# Altium schematic sheet DSDADC.SchDoc(DSDADC)
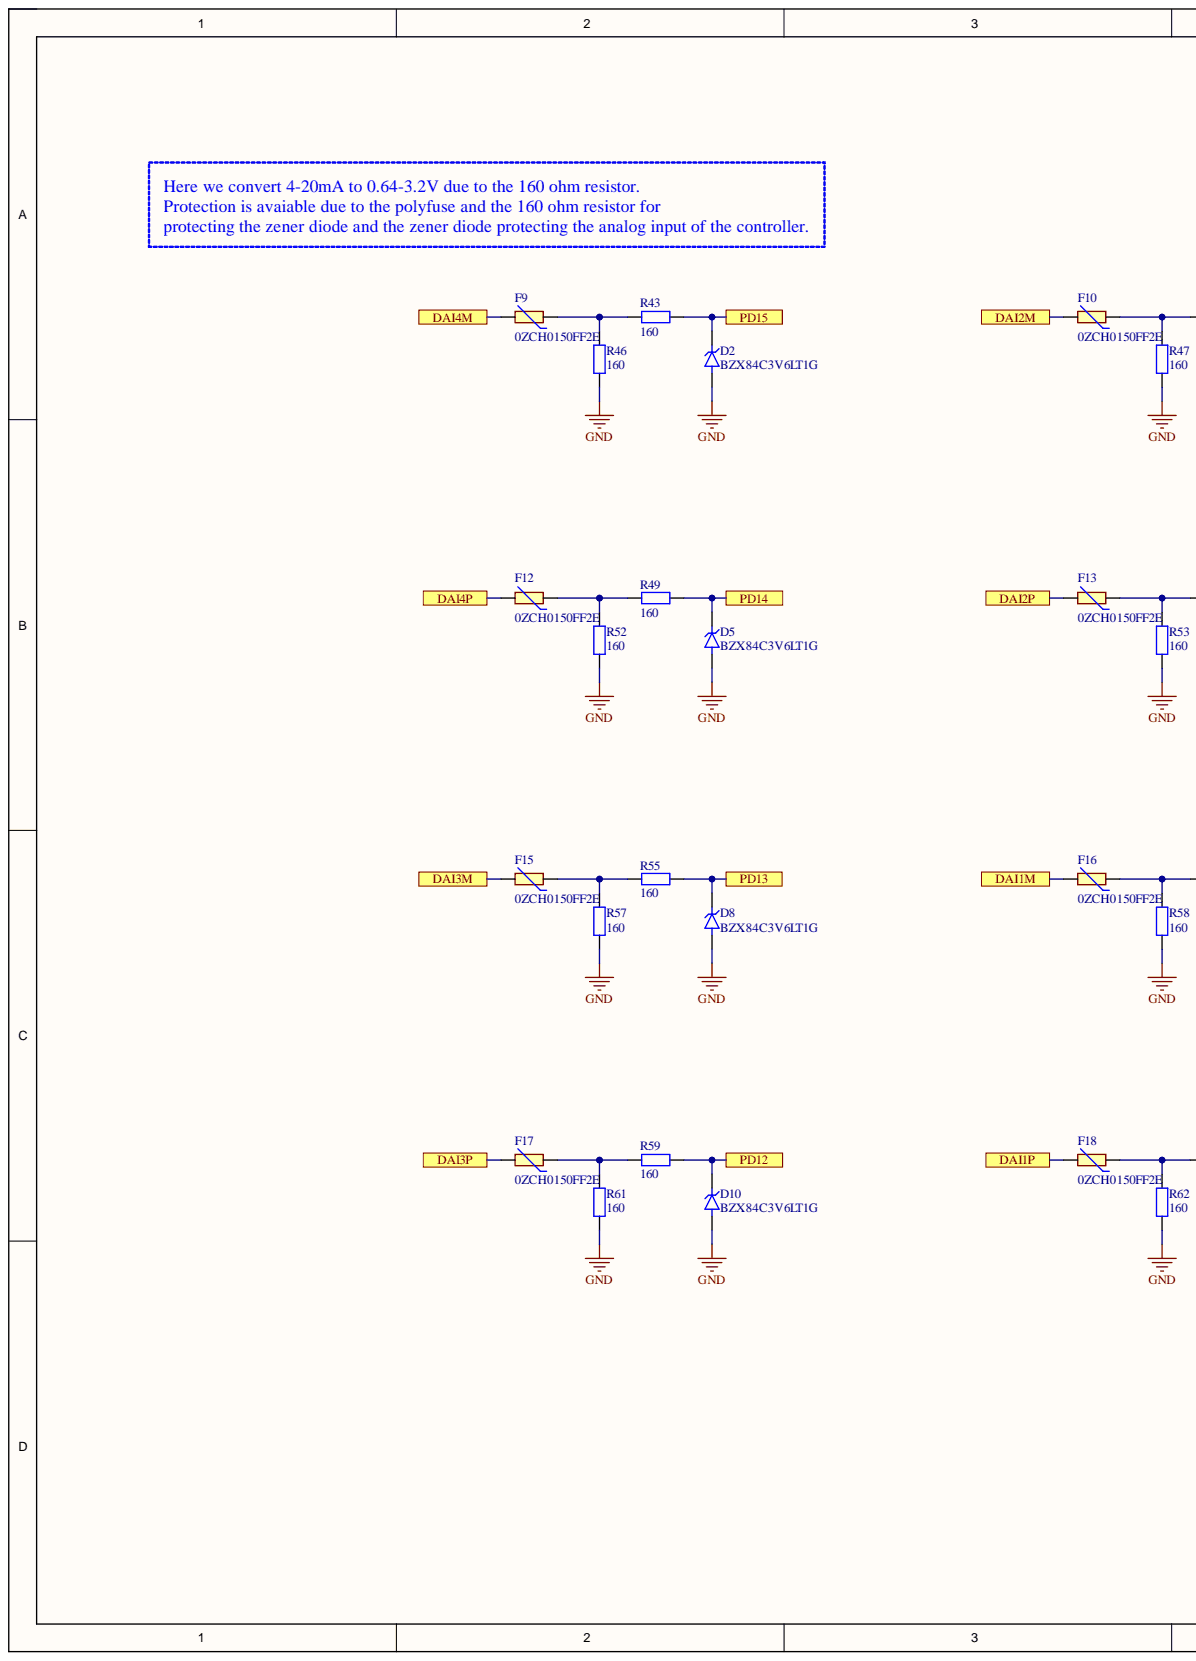
[diagram p0: DSDADC.SchDoc, left]
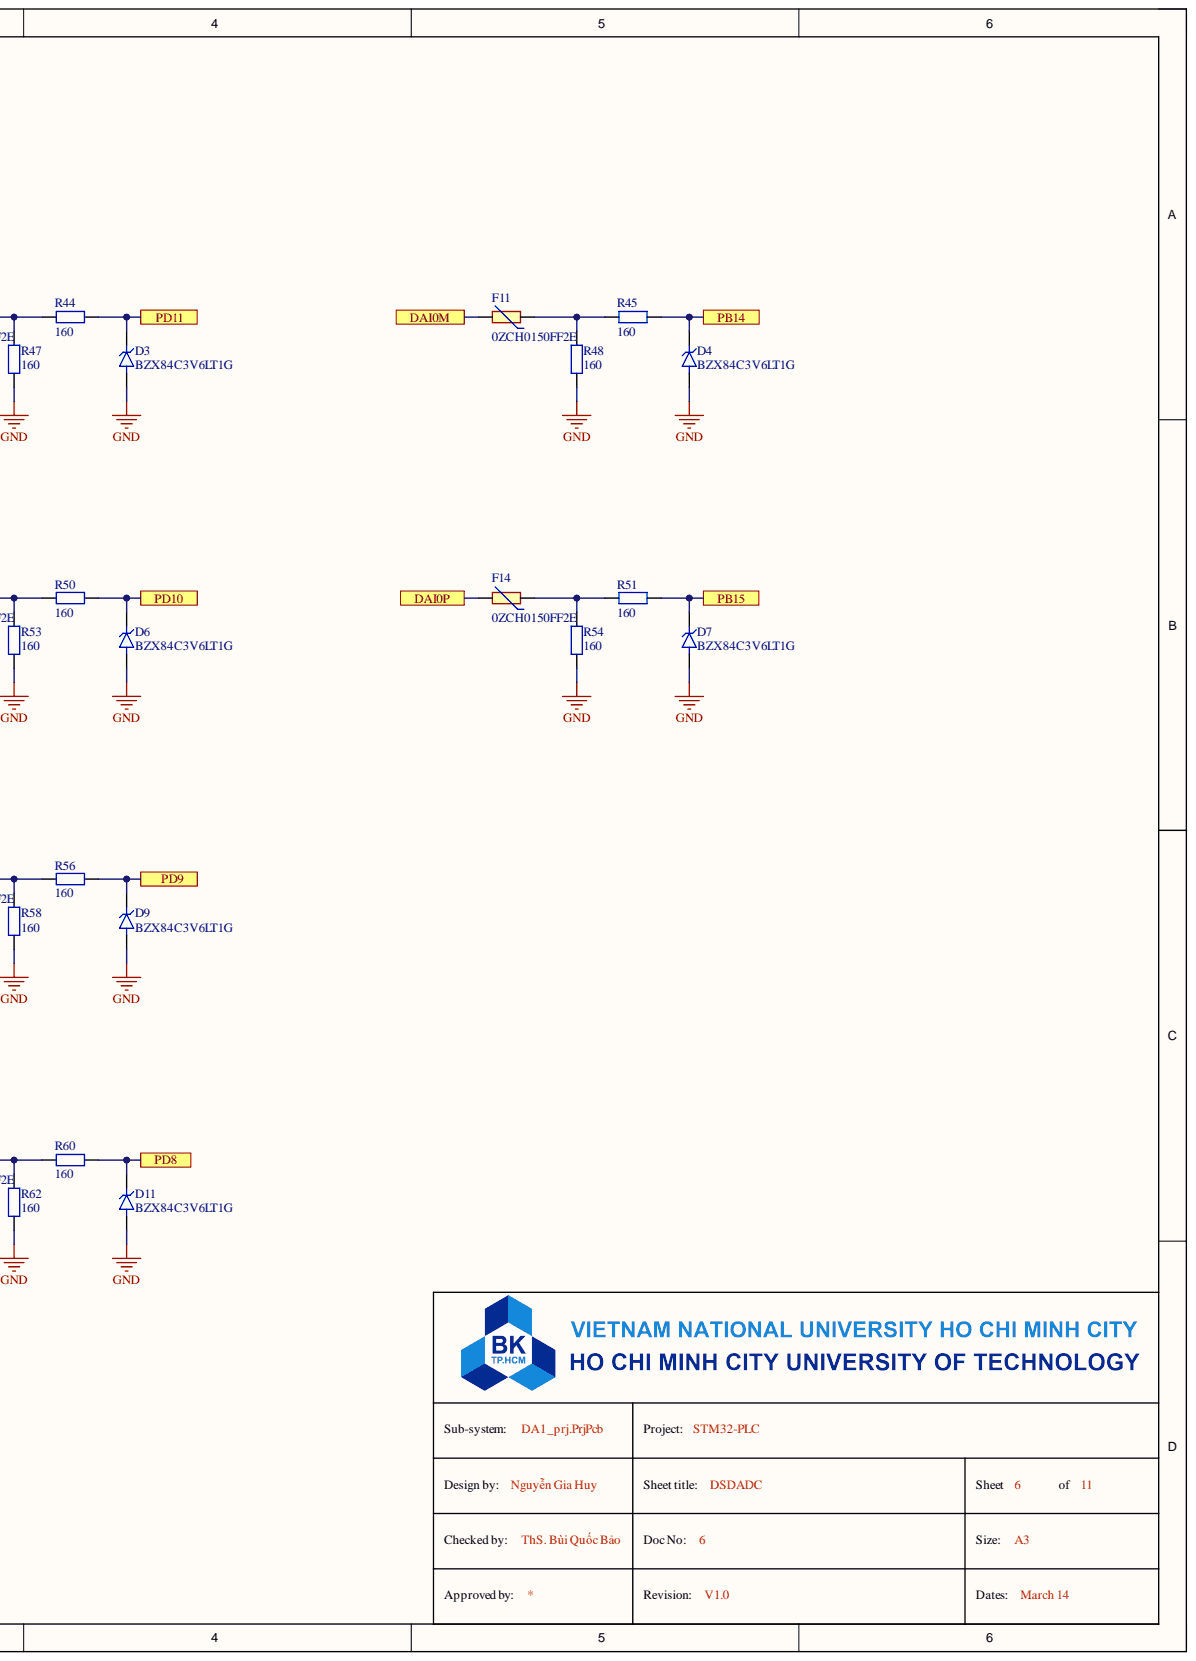
[diagram p1: DSDADC.SchDoc, right]

## Parts
D2  BZX84C3V6LT1G  [SOT95P240X111-3N]
D3  BZX84C3V6LT1G  [SOT95P240X111-3N]
D4  BZX84C3V6LT1G  [SOT95P240X111-3N]
D5  BZX84C3V6LT1G  [SOT95P240X111-3N]
D6  BZX84C3V6LT1G  [SOT95P240X111-3N]
D7  BZX84C3V6LT1G  [SOT95P240X111-3N]
D8  BZX84C3V6LT1G  [SOT95P240X111-3N]
D9  BZX84C3V6LT1G  [SOT95P240X111-3N]
D10  BZX84C3V6LT1G  [SOT95P240X111-3N]
D11  BZX84C3V6LT1G  [SOT95P240X111-3N]
F9  0ZCH0150FF2E  [FUSC3225X90N]
F10  0ZCH0150FF2E  [FUSC3225X90N]
F11  0ZCH0150FF2E  [FUSC3225X90N]
F12  0ZCH0150FF2E  [FUSC3225X90N]
F13  0ZCH0150FF2E  [FUSC3225X90N]
F14  0ZCH0150FF2E  [FUSC3225X90N]
F15  0ZCH0150FF2E  [FUSC3225X90N]
F16  0ZCH0150FF2E  [FUSC3225X90N]
F17  0ZCH0150FF2E  [FUSC3225X90N]
F18  0ZCH0150FF2E  [FUSC3225X90N]
R43  160  [tro smd 0603]
R44  160  [tro smd 0603]
R45  160  [tro smd 0603]
R46  160  [tro smd 0603]
R47  160  [tro smd 0603]
R48  160  [tro smd 0603]
R49  160  [tro smd 0603]
R50  160  [tro smd 0603]
R51  160  [tro smd 0603]
R52  160  [tro smd 0603]
R53  160  [tro smd 0603]
R54  160  [tro smd 0603]
R55  160  [tro smd 0603]
R56  160  [tro smd 0603]
R57  160  [tro smd 0603]
R58  160  [tro smd 0603]
R59  160  [tro smd 0603]
R60  160  [tro smd 0603]
R61  160  [tro smd 0603]
R62  160  [tro smd 0603]

## Ports
DAI0M, DAI0P, DAI1M, DAI1P, DAI2M, DAI2P, DAI3M, DAI3P, DAI4M, DAI4P, PB14, PB15, PD8, PD9, PD10, PD11, PD12, PD13, PD14, PD15

## Nets
GND: D2.1 D3.1 D4.1 D5.1 D6.1 D7.1 D8.1 D9.1 D10.1 D11.1 R46.1 R47.1 R48.1 R52.1 R53.1 R54.1 R57.1 R58.1 R61.1 R62.1
DAI0M: F11.2 ; port DAI0M
DAI0P: F14.2 ; port DAI0P
DAI1M: F16.2 ; port DAI1M
DAI1P: F18.2 ; port DAI1P
DAI2M: F10.2 ; port DAI2M
DAI2P: F13.2 ; port DAI2P
DAI3M: F15.2 ; port DAI3M
DAI3P: F17.2 ; port DAI3P
DAI4M: F9.2 ; port DAI4M
DAI4P: F12.2 ; port DAI4P
PB14: D4.3 R45.2 ; port PB14
PB15: D7.3 R51.2 ; port PB15
PD8: D11.3 R60.2 ; port PD8
PD9: D9.3 R56.2 ; port PD9
PD10: D6.3 R50.2 ; port PD10
PD11: D3.3 R44.2 ; port PD11
PD12: D10.3 R59.2 ; port PD12
PD13: D8.3 R55.2 ; port PD13
PD14: D5.3 R49.2 ; port PD14
PD15: D2.3 R43.2 ; port PD15
NetF9_1: F9.1 R43.1 R46.2
NetF10_1: F10.1 R44.1 R47.2
NetF11_1: F11.1 R45.1 R48.2
NetF12_1: F12.1 R49.1 R52.2
NetF13_1: F13.1 R50.1 R53.2
NetF14_1: F14.1 R51.1 R54.2
NetF15_1: F15.1 R55.1 R57.2
NetF16_1: F16.1 R56.1 R58.2
NetF17_1: F17.1 R59.1 R61.2
NetF18_1: F18.1 R60.1 R62.2

## Library data of the parts
F9-F18 = 0ZCH0150FF2E: 1210 SMT PPTC | 1.50 Ih | 6V; MF: Bel Fuse; Check_prices: https://www.snapeda.com/parts/0ZCH0150FF2E/Bel+Circuit+Protection/view-part/?ref=eda; Component Kind: Standard; Library Name: 0ZCH0150FF2E.IntLib; MP: 0ZCH0150FF2E; Package: Package; SnapEDA_Link: https://www.snapeda.com/parts/0ZCH0150FF2E/Bel+Circuit+Protection/view-part/?ref=snap
R43-R62 = Tro 0603 1% 10k: RES; Chung_Loai: Dán; Don_Vi: Con; Ma_Hang: MH006333; So_Chan: 2; Ten_Linh_Kien: Trở 0603 1% 10k; Component Kind: Standard; Library Name: AUSB.SchLib
D2-D11 = BZX84C3V6LT1G: Availability: Unavailable; Component Kind: Standard; Library Name: BZX84C3V6LT1G.IntLib; MP: BZX84C3V6LT1G; Package: None; Price: None
